# Altium schematic sheet Sheet11.SchDoc(Sheet11)
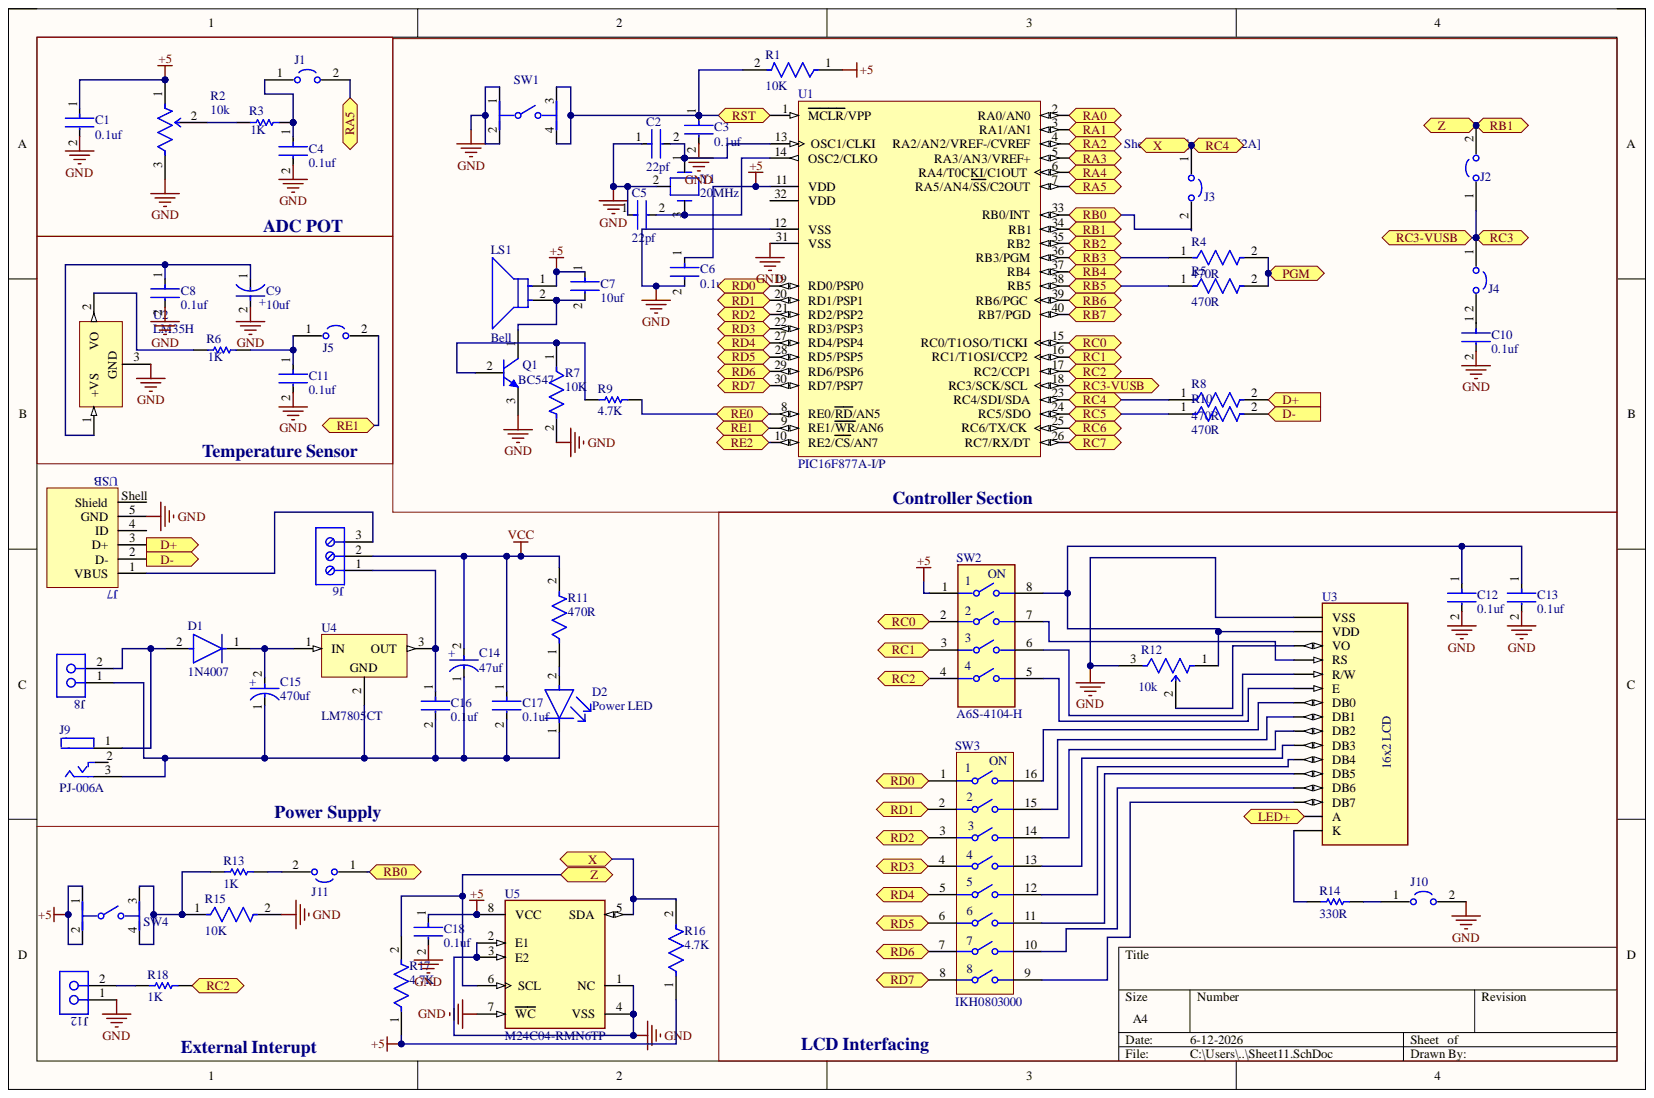
[diagram p0: Sheet11.SchDoc]

## Parts
C1  0.1uf  [FP-C1206C-EB-MFG]
C2  22pf  [FP-RDE2M1-MFG]
C3  0.1uf  [FP-C1206C-EB-MFG]
C4  0.1uf  [FP-C1206C-EB-MFG]
C5  22pf  [FP-RDE2M1-MFG]
C6  0.1uf  [FP-C1206C-EB-MFG]
C7  10uf  [FP-B32522C0106K000-MFG]
C8  0.1uf  [FP-C1206C-EB-MFG]
C9  10uf  [FP-OS-CON-TH-E12-d_0_6-MFG]
C10  0.1uf  [FP-C1206C-EB-MFG]
C11  0.1uf  [FP-C1206C-EB-MFG]
C12  0.1uf  [FP-C1206C-EB-MFG]
C13  0.1uf  [FP-C1206C-EB-MFG]
C14  47uf  [FP-OS-CON-TH-F13-d_0_6-MFG]
C15  470uf  [FP-RAD-TH-D_12_5_0_5-L_30-MFG]
C16  0.1uf  [FP-C1206C-EB-MFG]
C17  0.1uf  [FP-C1206C-EB-MFG]
C18  0.1uf  [FP-C1206C-EB-MFG]
D1  1N4007  [FP-1N4007-MFG]
D2  Power LED  [FP-XLUR12D-MFG]
J1  S1911-46R  [FP-S1911-46R-MFG]  (value not drawn)
J2  S1911-46R  [FP-S1911-46R-MFG]  (value not drawn)
J3  S1911-46R  [FP-S1911-46R-MFG]  (value not drawn)
J4  S1911-46R  [FP-S1911-46R-MFG]  (value not drawn)
J5  S1911-46R  [FP-S1911-46R-MFG]  (value not drawn)
J6  691250910003  [691250910003]  (value not drawn)
J7  USB  [629105150521]
J8  691307300002  [691307300002]  (value not drawn)
J9  PJ-006A  [FP-PJ-006A-MFG]
J10  S1911-46R  [FP-S1911-46R-MFG]  (value not drawn)
J11  S1911-46R  [FP-S1911-46R-MFG]  (value not drawn)
J12  691307300002  [691307300002]  (value not drawn)
LS1  Bell  [FP-SD1614T5-A1-MFG]
Q1  BC547  [ONSC-TO-92-3-29-11]
R1  10K  [FP-CF18-MFG]
R2  10k  [FP-3310R-MFG]
R3  1K  [RESA60-630X240]
R4  470R  [FP-AC1210-MFG]
R5  470R  [FP-AC1210-MFG]
R6  1K  [RESA60-630X240]
R7  10K  [FP-CF18-MFG]
R8  470R  [FP-AC1210-MFG]
R9  4.7K  [RESC1812(4532)_N]
R10  470R  [FP-AC1210-MFG]
R11  470R  [FP-AC1210-MFG]
R12  10k  [FP-3310R-MFG]
R13  1K  [RESA60-630X240]
R14  330R  [RESC3226X70X40ML20T20]
R15  10K  [FP-CF18-MFG]
R16  4.7K  [FP-RCMM02-MFG]
R17  4.7K  [FP-RCMM02-MFG]
R18  1K  [RESA60-630X240]
SW1  TL3305AF260QG  [FP-TL3305AF260QG-MFG]  (value not drawn)
SW2  A6S-4104-H  [FP-A6S-4104-H-MFG]
SW3  IKH0803000  [IKH0803000_MFG]
SW4  TL3305AF260QG  [FP-TL3305AF260QG-MFG]  (value not drawn)
U1  PIC16F877A-I/P  [PDIP600-P40]
U2  LM35H  [NDV0003A]
U3  (no value)  [MODULE_LCD-16X2]
U4  LM7805CT  [FAIR-TO-220-3]
U5  M24C04-RMN6TP  [FP-SO8N-SO-A-MFG]
Y1  20MHz  [ECS-4X-3IL]

## Ports
D+, D-, LED+, PGM, RA0, RA1, RA2, RA3, RA4, RA5, RB0, RB1, RB2, RB3, RB4, RB5, RB6, RB7, RC0, RC1, RC2, RC3, RC3-VUSB, RC4, RC5, RC6, RC7, RD0, RD1, RD2, RD3, RD4, RD5, RD6, RD7, RE0, RE1, RE2, RST, X, Z

## Nets
+5: C1.1 C6.1 C7.1 C18.1 LS1.1 R1.1 R2.1 R16.1 R17.1 SW2.1 SW4.1 SW4.2 U1.11(VDD) U5.8(VCC)
GND: C1.2 C2.1 C3.2 C4.2 C5.1 C6.2 C8.2 C9.2 C10.2 C11.2 C12.2 C13.2 C18.2 J7.5(GND) J10.2 J12.1 Q1.3 R2.3 R7.2 R12.3 R15.2 SW1.1 SW1.2 U1.12(VSS) U1.31(VSS) U2.3 U3.1(VSS) U5.1(NC) U5.2(E1) U5.3(E2) U5.4(VSS) U5.7(WC) Y1.2
VCC: C14.2 C17.1 J6.2 R11.2
PGM: R4.2 R5.2 ; port PGM
RA0: U1.2(RA0/AN0) ; port RA0
RA1: U1.3(RA1/AN1) ; port RA1
RA2: U1.4(RA2/AN2/VREF-/CVREF) ; port RA2
RA3: U1.5(RA3/AN3/VREF+) ; port RA3
RA4: U1.6(RA4/T0CKI/C1OUT) ; port RA4
RA5: J1.2 U1.7(RA5/AN4/SS/C2OUT) ; port RA5
RB0: J3.2 J11.1 U1.33(RB0/INT) ; port RB0
RB1: J2.2 R17.2 U1.34(RB1) U5.6(SCL) ; port RB1, port Z
RB2: U1.35(RB2) ; port RB2
RB3: R4.1 U1.36(RB3/PGM) ; port RB3
RB4: U1.37(RB4) ; port RB4
RB5: R5.1 U1.38(RB5) ; port RB5
RB6: U1.39(RB6/PGC) ; port RB6
RB7: U1.40(RB7/PGD) ; port RB7
RC0: SW2.2 U1.15(RC0/T1OSO/T1CKI) ; port RC0
RC1: SW2.3 U1.16(RC1/T1OSI/CCP2) ; port RC1
RC2: R18.1 SW2.4 U1.17(RC2/CCP1) ; port RC2
RC3: J2.1 J4.1 U1.18(RC3/SCK/SCL) ; port RC3, port RC3-VUSB
RC4: J3.1 R8.1 R16.2 U1.23(RC4/SDI/SDA) U5.5(SDA) ; port RC4, port X
RC5: R10.1 U1.24(RC5/SDO) ; port RC5
RC6: U1.25(RC6/TX/CK) ; port RC6
RC7: U1.26(RC7/RX/DT) ; port RC7
RD0: U1.19(RD0/PSP0) ; port RD0
RD1: SW3.2 U1.20(RD1/PSP1) ; port RD1
RD2: U1.21(RD2/PSP2) ; port RD2
RD3: U1.22(RD3/PSP3) ; port RD3
RD5: U1.28(RD5/PSP5) ; port RD5
RD6: U1.29(RD6/PSP6) ; port RD6
RD7: U1.30(RD7/PSP7) ; port RD7
RE0: R9.2 U1.8(RE0/RD/AN5) ; port RE0
RE1: J5.2 U1.9(RE1/WR/AN6) ; port RE1
RE2: U1.10(RE2/CS/AN7) ; port RE2
RST: C3.1 R1.2 SW1.3 SW1.4 U1.1(MCLR/VPP) ; port RST
NetC2_2: C2.2 U1.13(OSC1/CLKI) Y1.1
NetC4_1: C4.1 J1.1 R3.2
NetC5_2: C5.2 U1.14(OSC2/CLKO) Y1.3
NetC7_2: C7.2 LS1.2 Q1.1
NetC8_1: C8.1 C9.1 U2.1
NetC10_1: C10.1 J4.2
NetC11_1: C11.1 J5.1 R6.2
NetC12_1: C12.1 C13.1 R12.1 SW2.8 U3.2(VDD)
NetC14_1: C14.1 C15.1 C16.2 C17.2 D2.1 J8.1 J9.3 U4.2
NetC15_2: C15.2 D1.1 U4.1
NetC16_1: C16.1 J6.1 U4.3
NetD1_2: D1.2 J8.2 J9.1
NetD2_2: D2.2 R11.1
NetJ6_3: J6.3 J7.1(VBUS)
NetJ7_2: J7.2(D-) R10.2
NetJ7_3: J7.3(D+) R8.2
NetJ10_1: J10.1 R14.2
NetJ11_2: J11.2 R13.1
NetJ12_2: J12.2 R18.2
NetQ1_2: Q1.2 R7.1 R9.1
NetR2_2: R2.2 R3.1
NetR6_1: R6.1 U2.2
NetR12_2: R12.2 U3.3(VO)
NetR13_2: R13.2 R15.1 SW4.3 SW4.4
NetR14_1: R14.1 U3.16(K)
NetSW2_5: SW2.5 U3.6(E)
NetSW2_6: SW2.6 U3.5(R/W)
NetSW2_7: SW2.7 U3.4(RS)
NetSW3_9: SW3.9 U3.14(DB7)
NetSW3_10: SW3.10 U3.13(DB6)
NetSW3_11: SW3.11 U3.12(DB5)
NetSW3_12: SW3.12 U3.11(DB4)
NetSW3_13: SW3.13 U3.10(DB3)
NetSW3_14: SW3.14 U3.9(DB2)
NetSW3_15: SW3.15 U3.8(DB1)
NetSW3_16: SW3.16 U3.7(DB0)
N00009: ; port RD4
N00010: ; port LED+

Not connected: J7 4(ID) Shell(Shield); J9 2; SW3 1 3 4 5 6 7 8; U1 27(RD4/PSP4) 32(VDD); U3 15(A)

## Library data of the parts
C1 C3 C4 C6 C8 C10-C13 C16-C18 = CMP-03020-018297-1: C1206 0.10 μF U2J 20.00% 50 V; Manufacturer: KEMET; Manufacturer Part Number: C1206C104M5JACAUTO; Automotive Grade: Grade 0; Capacitance: 0.10 μF; Material: Ceramic; Temperature Coefficient: 15%; Tolerance: 0.2; Value: 0.10 μF; Voltage Rating: 50 V; Automotive: Yes; Category: Cap; Component Kind: Standard; ComponentLink2Description: Datasheet; ComponentLink2URL: https://content.kemet.com/datasheets/KEM_C1007_X8R_ULTRA_150C_SMD.pdf; Device Class L1: Passive Components; Device Class L2: Capacitors; Device Class L3: Ceramic Capacitors; Height: 0.88mm; Ipc Land Pattern Name: CAPC320160X78; Lead Free: Yes; Library Name: Altium Content Vault; Octopart: https://octopart.com/c1206c104m5jacauto-kemet-84732526; Package: C1206C-EB; RoHS: TRUE; Temperature: -55°C to +150°C; Temperature Characteristic: U2J; Temperature Range High: +150°C; Temperature Range Low: -55°C
C2 C5 = CMP-06035-034601-1: Ceramic Capacitor 22pF ±5% 1kV C0G Radial; Component Kind: Standard; Library Name: Altium Content Vault
C7 = CMP-00131-20738498-1: B32522C0106K000 Cap Film 10uF 63V PET 10% (18 X 9 X 17.5mm) Radial Stacked 15mm 125C Automotive Bulk; Capacitance: 10µF; Dielectric Material: Polyester; Lead Diameter: 800µm; Case/Package: Radial; Component Kind: Standard; Contact Plating: Tin; Depth: 9mm; Dielectric: PET; Height: 17.5mm; Lead Pitch: 15mm; Length: 18mm; Library Name: Altium Content Vault; Lifecycle Status: Production; Max Length: 18mm; Max Operating Temperature: 125°C; Max Width: 9mm; Min Operating Temperature: -55°C; Mount: Through Hole; NexarPartId: 20738498; Packaging: Bulk; REACH SVHC: Unknown; RoHS: Compliant; Schedule B: 8532250010, 8532250010; Termination: Radial; Tolerance: 10%; Voltage Rating: 63V; Voltage Rating (AC): 63V; Voltage Rating (DC): 63V
C9 = CMP-05428-000118-1: Conductive Polymer Aluminum Solid Capacitors (OS-CON) 18uF ±20% 100V RADIAL TH 8x12mm; ESR (Equivalent Series Resistance): 40mR; Capacitance: 18uF; Case/Package: Radial; Component Kind: Standard; Library Name: Altium Content Vault; Max Operating Temperature: 125°C; Min Operating Temperature: -55°C; Mount: Through Hole; Series: OS-CON™, SXE; Tolerance: 20%; Voltage Rating: 100V
C14 = CMP-05428-000334-1: Conductive Polymer Aluminum Solid Capacitors (OS-CON) 47uF ±20% 80V RADIAL TH 10x13mm; ESR (Equivalent Series Resistance): 28mR; Life (Hours): 1000Hour; Capacitance: 47uF; Case/Package: Radial; Component Kind: Standard; Library Name: Altium Content Vault; Max Operating Temperature: 125°C; Min Operating Temperature: -55°C; Mount: Through Hole; Packaging: Bulk; RoHS Compliant: Yes; Series: OS-CON™, SXE; Tolerance: 20%; Voltage Rating: 80V
C15 = CMP-05427-000129-1: Aluminum Electrolytic Capacitors (Radial Lead Type) 470uF ±20% 80V; Component Kind: Standard; Library Name: Altium Content Vault; RoHS Compliant: Yes
D1 = CMP-123542-000001-1: Rectifier diode 1000 V 1 A DO-41, ,; Manufacturer: Diotec; Capacitance: 15pF; Forward Current: 1A; Max Junction Temp: +175°C; Max Repetitive Reverse Voltage: 1000V; Max Surge Forward Current: 27A; Peak Reverse Current: 5uA; Value: 15pF; Automotive: No; Category: Diode; Component Kind: Standard; ComponentLink2Description: Datasheet; ComponentLink2URL: https://datasheet.octopart.com/1N4007-Diotec-datasheet-62346875.pdf; Device Class L1: Discrete Semiconductors; Device Class L2: Diodes; Device Class L3: Rectifier Diodes; Height: 2.8mm; Ipc Land Pattern Name: DIOAD888W100L520D280; Lead Free: Yes; Library Name: Altium Content Vault; Manufacturer Part Number: 1N4007; Octopart: https://octopart.com/1n4007-diotec-4040411; Package: 1N4007; Reverse Voltage: 1000V; RoHS: TRUE; Temperature: -50°C to +175°C; Temperature Range High: +175°C; Temperature Range Low: -50°C
D2 = CMP-02085-08376351-1: 627nm Red LED; 5x8.6mm, Through-Hole Mount with Red Diffused Round Lamp; Dominant Wavelength: 617nm; Forward Voltage: 1.9V; Luminous Intensity: 39mcd; Test Current: 10mA; Viewing Angle: 30°; Case/Package: Radial; Color: Red; Component Kind: Standard; Height: 8.6mm; Lens Color: Red; Lens Transparency: Diffused; Library Name: Altium Content Vault; Lifecycle Status: Production; Mount: Through Hole; RoHS: Compliant; Wavelength: 627nm
J1-J5 J10 J11 = CMP-15817-000009-1: JUMPER TIN SMD; Current Rating: 10A; Gender: Non-Gendered; Number of Contacts: 2; Pitch: 0.119inch; Color: Silver; Component Kind: Standard; Contact Material: Brass; Contact Plating: Tin; Depth: 2.51mm; Height: 0.038inch; Length: 6.85mm; Library Name: Altium Content Vault; Material: Brass; Number of Positions: 2; Number of Rows: 1; Orientation: Straight; Package Quantity: 4000; Packaging: Tape and Reel; Plating: Tin; REACH SVHC: No SVHC; RoHS Compliant: Yes; Series: S1; Termination: SMD/SMT
J6 = CMP-1502-02751-3: Terminal Block, 9.52MM Pitch, Horizontal, Rising Cage Clamp, 10-30 AWG, 3 Pin; Current Rating: 24A; Number of Contacts: 3; Voltage Rating: 300V; Component Kind: Standard; Height: 21.6mm; Library Name: Altium Content Vault; Max Operating Temperature: 120°C; Min Operating Temperature: -30°C; Number of Rows: 1; RoHS: Compliant
J7 = CMP-1502-03252-4: USB Connector, 5 Contact(s), Female, Right Angle, Surface Mount Terminal, Locking; Contact Resistance: 30mΩ; Current Rating: 3A; Gender: Receptacle; Insulation Resistance: 1GΩ; Number of Contacts: 5; Operating Supply Voltage: 30V; USB Standard: USB 2.0; Component Kind: Standard; Connector Type: USB; Contact Material: Gold; Contact Plating: Gold; Features: Shielded; Height: 2.86mm; Lead Free: Lead Free; Library Name: Altium Content Vault; Max Operating Temperature: 85°C; Min Operating Temperature: -40°C; Mount: Horizontal, Surface Mount; Number of Pins: 5; Orientation: Horizontal; Packaging: Tape and Reel; REACH SVHC: Unknown; RoHS: Compliant; Termination: SMD/SMT; Voltage Rating: 30V
J8 J12 = CMP-1502-01831-3: Terminal Block, Socket, 2Pos, Th Rohs Compliant: Yes; Current: 8A; Gender: Female; Number of Levels: 1; Number of Positions: 2; Pitch: 3.81mm; Voltage: 300V; Color: Green; Component Kind: Standard; Contact Plating: Tin; Lead Pitch: 3.81mm; Library Name: Altium Content Vault; Max Operating Temperature: 115°C; Min Operating Temperature: -40°C; Mount: Through Hole; Number of Pins: 2; Number of Positions Per Level: 2; Orientation: Vertical; Packaging: Box; RoHS: Compliant; Termination: Solder
J9 = CMP-14830-000002-1: CONN PWR JACK 2X5.5MM SOLDER; Manufacturer: CUI Inc; Conn Gender: Male; Conn Orientation: Vertical; Current Rating: 5A; Number Of Contacts: 1; Voltage Rating: 24V; Automotive: No; Category: Conn; Component Kind: Standard; ComponentLink2Description: Datasheet; ComponentLink2URL: https://upverter.com/datasheet/9ed293f1fa29a0cd2acf14ef7c71896f95dae00a.pdf; Contact Material: Nickel,Copper; Device Class L1: Connectors; Device Class L2: Power Connectors; Device Class L3: unset; Digikey Description: CONN PWR JACK 2X5.5MM SOLDER; Height: 13.5mm; Lead Free: Yes; Library Name: Altium Content Vault; Manufacturer Part Number: PJ-006A; Mouser Description: 2.0 mm Center Pin, 5.0 A, Vertical, Through Hole, Dc Power Jack Connector; Octopart: https://octopart.com/pj-006a-cui-286899; Package: PJ-006A; RoHS: TRUE; Temperature: -25°C to +70°C; Temperature Range High: +70°C; Temperature Range Low: -25°C
LS1 = CMP-08413-000005-1: BUZZER MAGNETIC 5V 80DB 2048HZ 1; Frequency: 2.048kHz; Operating Supply Current: 80mA; Component Kind: Standard; Library Name: Altium Content Vault; Max Operating Temperature: 85°C; Min Operating Temperature: -40°C; Mount: Panel; Sensing Method: Magnetic; Termination: PC Pins; Voltage Rating: 5V
Q1 = CMP-1048-01464-1: Amplifier Transistor, NPN Silicon, 3-Pin TO-92, Pb-Free, Bulk Box; Manufacturer: On Semiconductor; PartNumber: BC547CG; fT Min (MHz): 150; IC Continuous (A): 0.1; Component Kind: Standard; ComponentLink1Description: Manufacturer URL; ComponentLink1URL: http://www.onsemi.com/; ComponentLink2Description: Datasheet; ComponentLink2URL: http://www.onsemi.com/pub_link/Collateral/BC546-D.PDF; ComponentLink3Description: Package Specification; ComponentLink3URL: http://www.onsemi.cn/pub_link/Collateral/2N6426-D.PDF; DatasheetVersion: Rev. 7; Library Name: Altium Content Vault; Mounting Technology: Through Hole; PackageDescription: 3-Pin Transistor Outline, Body 4.825 x 3.685 mm, Pitch 1.27 mm; PackageReference: TO-92-3-29-11_AM_SL; PackageVersion: Rev. AM; Packing: Bulk Box; Polarity: NPN; RoHS: TRUE
R1 R7 R15 = CMP-26528-000002-1: RES 10K OHM 1/8W 5% CF AXIAL; Manufacturer: Stackpole Electronics; Manufacturer Part Number: CF18JT10K0; Power Rating: 0.125W; Resistance: 10kΩ; Temperature Coefficient: 0-500ppm/°C; Value: 10kΩ; Voltage Rating: 250V; Automotive: No; Category: Res; Component Kind: Standard; ComponentLink2Description: Datasheet; ComponentLink2URL: http://www.seielect.com/Catalog/SEI-CF_CFM.pdf; Composition: Carbon Film; Device Class L1: Passive Components; Device Class L2: Resistors; Device Class L3: Through-Hole Resistors; Digikey Description: RES 10K OHM 1/8W 5% CF AXIAL; Height: 2mm; Ipc Land Pattern Name: RESAD656W65L360D200; Lead Free: Yes; Library Name: Altium Content Vault; Octopart: https://octopart.com/cf18jt10k0-stackpole+electronics-19205642; Package: CF18; RoHS: TRUE; Series: CF; Temperature: -55°C to +155°C; Temperature Range High: +155°C; Temperature Range Low: -55°C; Tolerance: 5%
R2 R12 = CMP-07234-000023-1: POT 10K OHM 1/4W PLASTIC LINEAR; Actuator Diameter: 0.125inch; Actuator Length: 0.22inch; Max Power Dissipation: 250mW; Number of Gangs: 1; Resistance: 10kR; Temperature Coefficient: 1000ppm/°C; Tolerance: 20%; Component Kind: Standard; Composition: Conductive Plastic; Contact Plating: Tin; Library Name: Altium Content Vault; Max Operating Temperature: 125°C; Min Operating Temperature: -40°C; Mount: Through Hole; Number of Turns: 1; Package Quantity: 50; Packaging: Bulk; Power Rating: 250mW; REACH SVHC: No SVHC; Taper: Linear; Termination: Pin; Type: Potentiometer; Weight: 4.5g
R3 R6 R13 R18 = CMP-1659-00075-1: Axial Resistor, 1 KOhm, +/- 1%, 250 mW, -55 to 155 degC, 2-Pin THD, RoHS, Bulk; Power Rating: 250 mW; Resistance: 10 kohm; Voltage Rating: 250 V; Case\Package: Axial; Component Kind: Standard; ComponentLink1Description: Manufacturer URL; ComponentLink1URL: http://www.yageo.com/; ComponentLink2Description: Datasheet; ComponentLink2URL: http://www.yageo.com/documents/recent/Yageo%20LR_MFR_2013.pdf; DatasheetVersion: Apr-13; Length: 0.248 inch; Library Name: Altium Content Vault; Max Operating Temperature: 155 degC; Min Operating Temperature: -55 degC; Package Quantity: 200; PackageDescription: 2-Pin Axial Lead, Dia 2.4 mm, Body Length 6.3 mm; PackageReference: MFR-25; Packaging: Bulk; RoHSCompliant: true; Tolerance: 1%
R4 R5 R8 R10 R11 = CMP-03412-021586-1: RES SMD 470 OHM 5% 1/2W 1210; Manufacturer: Yageo; Manufacturer Part Number: AC1210JR-07470RL; Automotive Grade: Grade 0; Power Rating: 0.5W; Resistance: 470Ω; Tolerance: 0.05; Value: 470Ω; Voltage Rating: 200V; Automotive: Yes; Category: Res; Component Kind: Standard; ComponentLink2Description: Datasheet; ComponentLink2URL: http://www.yageo.com/NewPortal/yageodocoutput?fileName=/pdf/R-Chip/PYu-AC_51_RoHS_L_6.pdf; Composition: Thick Film; Device Class L1: Passive Components; Device Class L2: Resistors; Device Class L3: Chip SMD Resistors; Digikey Description: RES SMD 470 OHM 5% 1/2W 1210; Footprint Url: http://www.yageo.com/exep/pages/download/literatures/PYu-R_Mount_9.pdf; Height: 0.65mm; Ipc Land Pattern Name: RESC310260X55; Lead Free: Yes; Library Name: Altium Content Vault; Octopart: https://octopart.com/ac1210jr-07470rl-yageo-71368950; Package: AC1210; RoHS: TRUE; Series: AC; Temperature: -55°C to +155°C; Temperature Range High: +155°C; Temperature Range Low: -55°C
R9 = CMP-1016-00090-1: 4K7 0.75W 5% 1812 (4532 Metric)  SMD; Case-EIA: 1812; Case-Metric: 4532; Value: 4700.00 Ohm; Component Kind: Standard; Library Name: Altium Content Vault; Power: 0.75 W; Technology: SMT; Tolerance: 5 %
R14 = CMP-2005-02232-1: Manufacturer: KOA Speer; Part Number: RK73B2ETTE331J; Component Kind: Standard; Library Name: Altium Content Vault
R16 R17 = CMP-02408-000034-1: RES 4.7K OHM 2% 1/2W AXIAL; Resistance: 4.7kR; Temperature Coefficient: 100ppm/°C; Case/Package: Molded; Component Kind: Standard; Contact Plating: Tin; Diameter: 2.5mm; Length: 6.5mm; Library Name: Altium Content Vault; Mount: Through Hole; Resistor Type: Metal Film; RoHS Compliant: Yes; Tolerance: 2%
SW1 SW4 = CMP-17879-000010-1: SWITCH TACTILE SPST-NO 50MA 12V; Actuator Type: Standard; Illumination Type: Non-Illuminated; Mechanical Life: 200000Cycles; Operating Force: 260gf; Switch Function: Off-Mom; Switch Travel: 0.2mm; Throw Configuration: SPST; Component Kind: Standard; Lead Free: Lead Free; Library Name: Altium Content Vault; Max Operating Temperature: 70°C; Min Operating Temperature: -20°C; Mount: Surface Mount; Packaging: Tape and Reel; Termination: Gull Wing
SW2 = CMP-08827-000006-1: SWITCH SLIDE DIP SPST 25MA 24V; Manufacturer: Omron Electronics Inc-EMC Div; Contact Resistance: 200mΩ; Electromechanical Life: 1000Cycles; Number Of Circuits: 4; Standoff Height: 0.7mm; Ambient Temperature Range High: +70°C; Ambient Temperature Range Low: -20°C; Automotive: No; Category: Switch; Component Kind: Standard; ComponentLink2Description: Datasheet; ComponentLink2URL: https://omronfs.omron.com/en_US/ecb/products/pdf/en-a6s.pdf; Contact Current Rating: 25mA; Device Class L1: Electromechanical; Device Class L2: Switches; Device Class L3: DIP Switches; Digikey Description: SWITCH SLIDE DIP SPST 25MA 24V; Height: 4.5mm; Lead Free: Yes; Library Name: Altium Content Vault; Manufacturer Part Number: A6S-4104-H; Mount: Surface Mount; Mouser Description: DIP Switches / SIP Switches Dip Switch; Octopart: https://octopart.com/a6s-4104-h-omron-1480027; Package: 0; RoHS: TRUE; Temperature: -20°C to +70°C; Temperature Range High: +70°C; Temperature Range Low: -20°C; Throw Configuration: SPST; Voltage Rating Dc: 24V
SW3 = CMP-00638-06323270-1: Switch DIP ON OFF SPST 8 Recessed Slide 0.025A 24VDC Gull Wing 1.27mm SMD Tube; Current Rating: 25mA; Electrical Life: 1kcycles; Insulation Resistance: 100MΩ; Number of Positions: 8; Voltage Rating (DC): 50V; Washable: Yes; Component Kind: Standard; ComponentLink1Description: Manufacturer's Website; ComponentLink1URL: http://www.apem.com/; ComponentLink2Description: Datasheet URL; ComponentLink2URL: https://www.apem.com/media/apemProduct/IKH_Series_Dual_in_Line_2018.pdf; Contact Material: Bronze; HelpURL: https://www.apem.com/media/apemProduct/IKH_Series_Dual_in_Line_2018.pdf; Library Name: Altium Content Vault; Max Operating Temperature: 70°C; Min Operating Temperature: -20°C; Mount: Surface Mount; Number of Pins: 8; RoHS: Compliant; Terminal Pitch: 1.27mm; Termination: Solder; Throw Configuration: SPST
U1 = CMP-0115-00183-1: Enhanced FLASH Microcontroller, 8K (x14-Bit words) FLASH, 368 Bytes RAM, 40-Pin PDIP, Standard VDD Range, Industrial Temperature; ComponentAvailability: Unknown. Contact manufacturer to determine status.; Component Kind: Standard; DatasheetVersion: revB, Oct-2003; Library Name: Altium Content Vault; PackageDescription: 40-Lead Plastic Dual In-Line (P) - 600 mil Body [PDIP]; PackageReference: PDIP600-P40; PackageVersion: revBB, Aug-2009
U2 = CMP-0066-00004-3: Precision Centigrade Temperature Sensor, 3-pin TO-46; Manufacturer: Texas Instruments; CompType: Temp Sensor IC; PackageTopMarking: NSXYTTE# LM35H; PowerWise: N; Code_JEDEC: TO-46; Component Kind: Standard; ComponentLink1Description: Datasheet URL; ComponentLink1URL: http://www.ti.com/general/docs/lit/getliterature.tsp?genericPartNumber=LM35&fileType=pdf; Library Name: Altium Content Vault; PackageDescription: Metal Can, TO-46, 3 Lead, .100in Dia, P.C.; PackageReference: NDV0003A; PinCount: 3; RoHS: N
U3 = LCD-16X2: Display Development Tools 16x2 Black on Green Char LCD w Backlight; MF: Gravitech; Check_prices: https://www.snapeda.com/parts/LCD-16X2/Gravitech/view-part/?ref=eda; Component Kind: Standard; Library Name: LCD-16X2.IntLib; MP: LCD-16X2; Package: None; SnapEDA_Link: https://www.snapeda.com/parts/LCD-16X2/Gravitech/view-part/?ref=snap
U4 = CMP-2000-04938-1: Positive Voltage Regulator, 5 V, 1 A, -40 to 125 degC, 3-Pin TO-220, RoHS, Tube; Manufacturer: FairchildSemiconductor; Accuracy: ±4%; Line Regulation: 100mV; Maximum Input Voltage: 35V; Maximum Output Current: 2.2(Typ)A; Maximum Quiescent Current: 8mA; Number of Outputs: 1; Output Type: Fixed; Output Voltage: 5V; Rad Hard: No; Typical Dropout Voltage @ Current: 2@1AV; Category: LinearRegulator; Component Kind: Standard; Datasheet URL: https://datasheet.ciiva.com/26904/lm7805ct-26904988.pdf; Datasheet Version: Aug-12; Library Name: Altium Content Vault; Load Regulation: 100mV; Manufacturer URL: https://www.fairchildsemi.com/; Mounting: ThroughHole; Operating Temperature: -40to125degC; Package: 3TO-220AB; Package Description: 3-Pin Through Hole Device, Body 10 x 4.5 mm, Pitch 2.54 mm; Package Reference: TO-220; Package URL: https://www.fairchildsemi.com/package-drawings/TO/TO220B03.pdf; Packaging: Rail/Tube; Polarity: Positive; Type: Linear
U5 = CMP-12142-000003-1: IC EEPROM 4K I2C 400KHZ 8SO; Manufacturer: STMicroelectronics; Max Supply Voltage: 5.5V; Memory Size: 4Kbits; Min Supply Voltage: 1.8V; Nominal Supply Current: 0.5-0.8mA; Standoff Height: 0.1mm; Ambient Temperature Range High: +85°C; Ambient Temperature Range Low: -40°C; Automotive: No; Category: IC; Component Kind: Standard; ComponentLink2Description: Datasheet; ComponentLink2URL: https://www.st.com/resource/en/datasheet/m24c04-r.pdf; Device Class L1: Integrated Circuits (ICs); Device Class L2: Memory; Device Class L3: EEPROM; Digikey Description: IC EEPROM 4K I2C 400KHZ 8SO; Frequency: 400kHz; Height: 1.75mm; Interface: I2C; Lead Free: Yes; Library Name: Altium Content Vault; Manufacturer Part Number: M24C04-RMN6TP; Memory Type: EEPROM; Mouser Description: M24C04-R Series 4 Kb I2C 2 Wire (512 K x 8) 1.8 V Serial EEPROM SMT - SOIC-8; Octopart: https://octopart.com/m24c04-rmn6tp-stmicroelectronics-7870337; Package: SO8N-SO-A; RoHS: TRUE; Temperature: -40°C to +85°C; Temperature Range High: +85°C; Temperature Range Low: -40°C
Y1 = CMP-1669-00001-2: CRYSTAL 20.000MHZ 20PF 49US; Manufacturer: ECS; PartNumber: ECS-200-20-4X; Component Kind: Standard; ComponentLink1Description: Datasheet; ComponentLink1URL: http://www.ecsxtal.com/store/pdf/hc-49usx.pdf; Library Name: Altium Content Vault; PackageReference: 4X-3IL
